# Altium schematic sheet DVT-Atlas-Driver1.SchDoc(DVT-Atlas-Driver1)
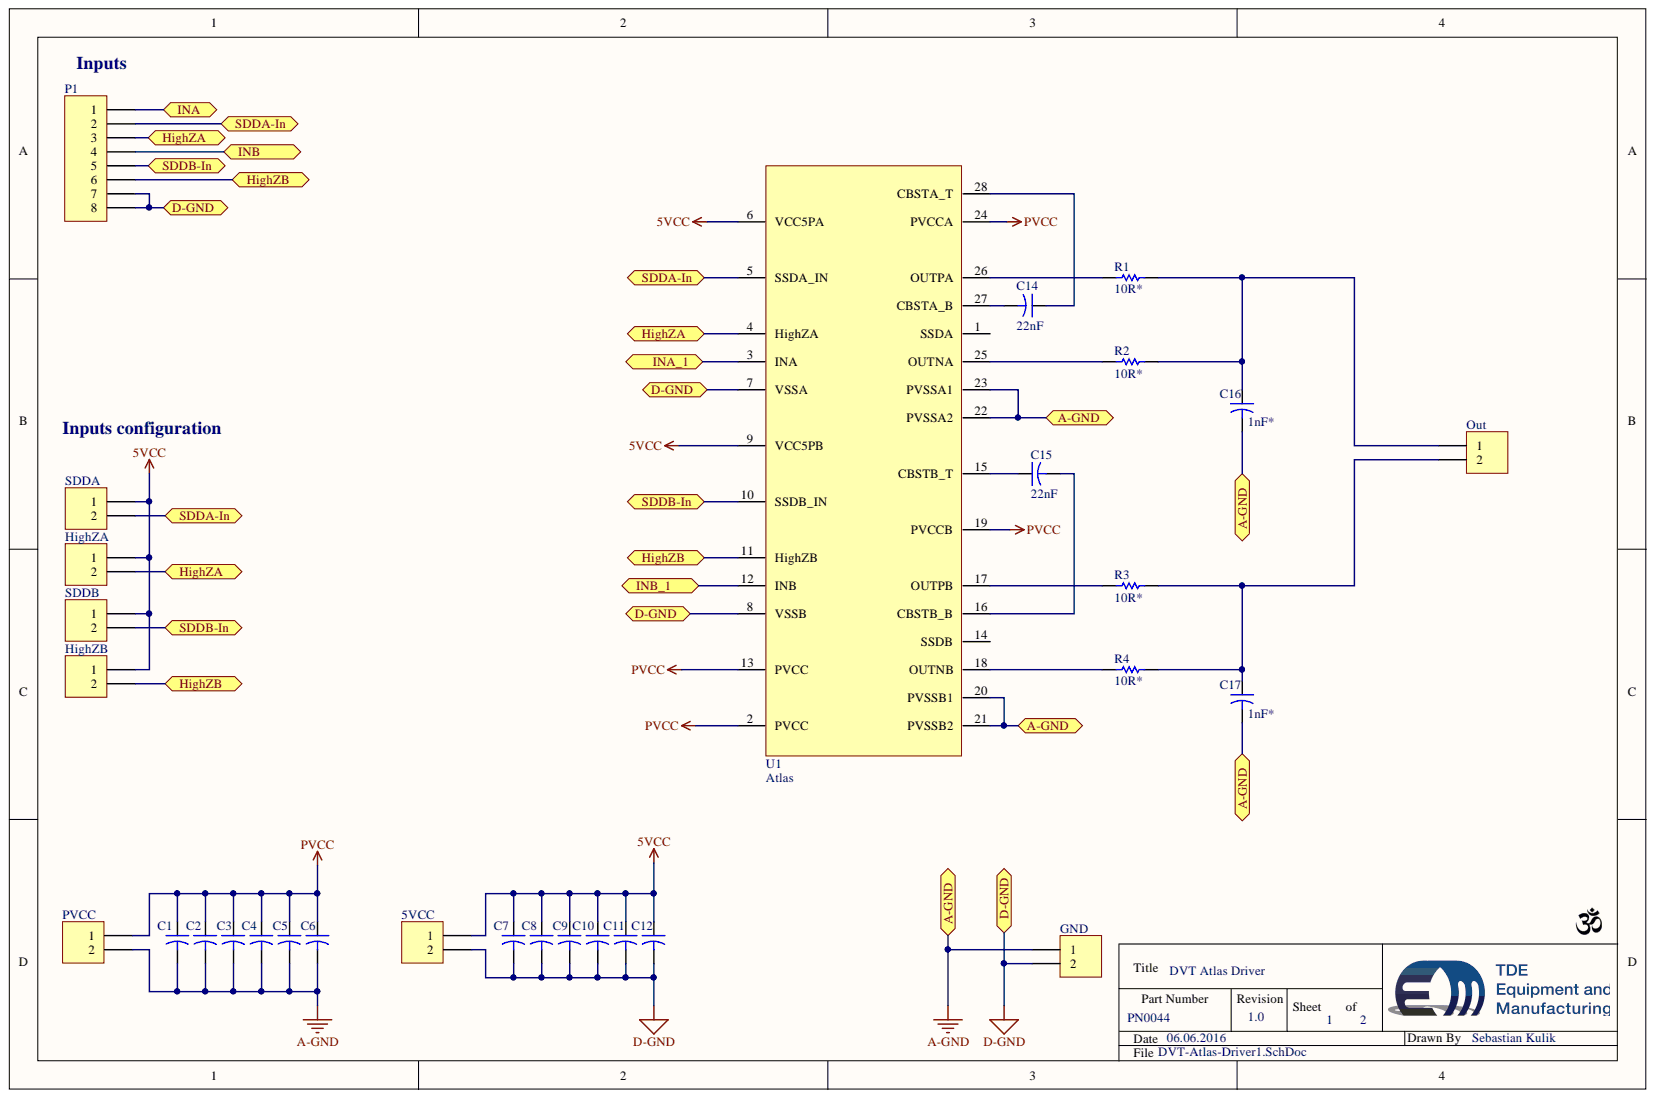
[diagram p0: DVT-Atlas-Driver1.SchDoc]

## Parts
5VCC  PHOENIX_1715721  [Phoenix-2-5_08]  (value not drawn)
*  Om  [Om-5mm]  (value not drawn)
+  Om  [Om-5mm]  (value not drawn)
C1  Capacitor-Dummy-THT  [Dummy-10mm]  (value not drawn)
C2  Capacitor-Dummy-THT  [Dummy-10mm]  (value not drawn)
C3  Capacitor-Dummy-THT  [Dummy-10mm]  (value not drawn)
C4  Capacitor-Dummy-THT  [Dummy-10mm]  (value not drawn)
C5  Capacitor-Dummy-THT  [Dummy-10mm]  (value not drawn)
C6  Capacitor-Dummy-THT  [Dummy-10mm]  (value not drawn)
C7  Capacitor-Dummy-THT  [Dummy-10mm]  (value not drawn)
C8  Capacitor-Dummy-THT  [Dummy-10mm]  (value not drawn)
C9  Capacitor-Dummy-THT  [Dummy-10mm]  (value not drawn)
C10  Capacitor-Dummy-THT  [Dummy-10mm]  (value not drawn)
C11  Capacitor-Dummy-THT  [Dummy-10mm]  (value not drawn)
C12  Capacitor-Dummy-THT  [Dummy-10mm]  (value not drawn)
C14  value 22nF  [Dummy-10mm]  (hidden comment: Capacitor-Dummy-THT)
C15  value 22nF  [Dummy-10mm]  (hidden comment: Capacitor-Dummy-THT)
C16  value 1nF*  [Dummy-10mm]  (hidden comment: Capacitor-Dummy-THT)
C17  value 1nF*  [Dummy-10mm]  (hidden comment: Capacitor-Dummy-THT)
GND  PHOENIX_1715721  [Phoenix-2-5_08]  (value not drawn)
HighZA  Plug_2_180_2.54  [HDR1X2]  (value not drawn)
HighZB  Plug_2_180_2.54  [HDR1X2]  (value not drawn)
Out  PHOENIX_1715721  [Phoenix-2-5_08]  (value not drawn)
P1  Plug_08_2.54  [HDR1X8_2.54]  (value not drawn)
PVCC  PHOENIX_1715721  [Phoenix-2-5_08]  (value not drawn)
R1  value 10R*  [Dummy-10mm]  (hidden comment: Resistor-Dummy-THT)
R2  value 10R*  [Dummy-10mm]  (hidden comment: Resistor-Dummy-THT)
R3  value 10R*  [Dummy-10mm]  (hidden comment: Resistor-Dummy-THT)
R4  value 10R*  [Dummy-10mm]  (hidden comment: Resistor-Dummy-THT)
SDDA  Plug_2_180_2.54  [HDR1X2]  (value not drawn)
SDDB  Plug_2_180_2.54  [HDR1X2]  (value not drawn)
U1  Atlas  [SOIC28]

## Ports
A-GND, D-GND, HIGHZA, HIGHZB, INA, INA_1, INB, INB_1, SDDA-IN, SDDB-IN

## Nets
5VCC: 5VCC.1 C7.1 C8.1 C9.1 C10.1 C11.1 C12.1 HighZA.1 HighZB.1 SDDA.1 SDDB.1 U1.6(VCC5PA) U1.9(VCC5PB)
PVCC: C1.1 C2.1 C3.1 C4.1 C5.1 C6.1 PVCC.1 U1.2(PVCC) U1.13(PVCC) U1.19(PVCCB) U1.24(PVCCA)
A-GND: C1.2 C2.2 C3.2 C4.2 C5.2 C6.2 C16.2 C17.2 GND.1 PVCC.2 U1.20(PVSSB1) U1.21(PVSSB2) U1.22(PVSSA2) U1.23(PVSSA1) ; port A-GND
D-GND: 5VCC.2 C7.2 C8.2 C9.2 C10.2 C11.2 C12.2 GND.2 P1.7 P1.8 U1.7(VSSA) U1.8(VSSB) ; port D-GND
INA: P1.1 ; port INA
INA_1: U1.3(INA) ; port INA_1
INB: P1.4 ; port INB
INB_1: U1.12(INB) ; port INB_1
NetC14_1: C14.1 U1.28(CBSTA_T)
NetC14_2: C14.2 U1.27(CBSTA_B)
NetC15_1: C15.1 U1.15(CBSTB_T)
NetC15_2: C15.2 U1.16(CBSTB_B)
NetC16_1: C16.1 Out.1 R1.2 R2.2
NetC17_1: C17.1 Out.2 R3.2 R4.2
NetHighZA_2: HighZA.2 P1.3 U1.4(HighZA) ; port HIGHZA
NetHighZB_2: HighZB.2 P1.6 U1.11(HighZB) ; port HIGHZB
NetP1_2: P1.2 SDDA.2 U1.5(SSDA_IN) ; port SDDA-IN
NetP1_5: P1.5 SDDB.2 U1.10(SSDB_IN) ; port SDDB-IN
NetR1_1: R1.1 U1.26(OUTPA)
NetR2_1: R2.1 U1.25(OUTNA)
NetR3_1: R3.1 U1.17(OUTPB)
NetR4_1: R4.1 U1.18(OUTNB)

Not connected: U1 1(SSDA) 14(SSDB)

## Library data of the parts
5VCC GND Out PVCC = 2Pol_1715721: Phoenix, 2 pol, 5.08mm, 22A, 715721; Deliverer PartNr: 3041529; Deliverer: Farnell; TDE-PN: CON0008; Component Kind: Standard; LatestRevisionDate: 02.05.2014; LatestRevisionNote: Re-released for DXP Platform.; Library Name: Connectors.IntLib; Manufacturer: Phoenix; Manufacturer PartNr: 1715721; PackageDescription: Phoenix-2-5_08; Signal Integrity: Connector; Publisher: Sebastian Kulik
C14 C15 = Capacitor-Dummy-THT: Deliverer PartNr: 537-3814; Deliverer: RS; Component Kind: Standard; Description: Capacitor-Dummy-THT; Library Name: Capacitors.IntLib; Manufacturer: -; Manufacturer PartNr: -; PackageDescription: -; Part Description: Capacitor-Dummy-THT; Published: 08.12.2015; Signal Integrity: Cap; Simulation: CAP; TDE-PN: -; Publisher: Sebastian Kulik
C16 C17 = Capacitor-Dummy-THT: Deliverer PartNr: 538-1168; Deliverer: RS; Component Kind: Standard; Description: Capacitor-Dummy-THT; Library Name: Capacitors.IntLib; Manufacturer: -; Manufacturer PartNr: -; PackageDescription: -; Part Description: Capacitor-Dummy-THT; Price: 0.116/5; Published: 08.12.2015; Signal Integrity: Cap; Simulation: CAP; TDE-PN: -; Publisher: Sebastian Kulik
HighZA HighZB SDDA SDDB = Plug_2_180_2.54: Plug, 2-Pin; Deliverer PartNr: 828-1581; Manufacturer: WE; Manufacturer PartNr: 61300211121; Deliverer: RS; TDE-PN: CON0010; Component Kind: Standard; LatestRevisionDate: 14.05.2014; LatestRevisionNote: Re-released for DXP Platform.; Library Name: Connectors.IntLib; Price: 0.118/5; Signal Integrity: Connector; Publisher: Sebastian Kulik
P1 = Plug_08_2.54: Plug, 08-Pin; Deliverer PartNr: 61300811121; Deliverer: WE; TDE-PN: CON0035; Component Kind: Standard; LatestRevisionDate: 11.11.2014; LatestRevisionNote: Re-released for DXP Platform.; Library Name: Connectors.IntLib; Manufacturer: WE; Manufacturer PartNr: 61300811121; Signal Integrity: Connector; Publisher: Sebastian Kulik
R1-R4 = Resistor-Dummy-THT: Deliverer PartNr: 148-017; Deliverer: RS; Component Kind: Standard; Description: Resistor-Dummy-THT; Library Name: Resistors.IntLib; Manufacturer: -; Manufacturer PartNr: -; PackageDescription: -; Part Desicription: Resistor-Dummy-THT; Price: 0.044/10; Published: 08.12.2015; Signal Integrity: Res; Simulation: RESISTOR; TDE-PN: -; Publisher: Sebastian Kulik
U1 = CHT_TIT3345E_CSOIC28_T: Gate driver, 30V, HT; Deliverer PartNr: CHT-TIT3345E-CSOIC28-T; Manufacturer: CISSOID; Deliverer: Future; TDE-PN: IC0075; Component Kind: Standard; Library Name: ICs.IntLib; Manufacturer PartNr: CHT-TIT3345E-CSOIC28-T; PackageDescription: SOIC28; Price: 481.89; Published: 12.05.2016; Publisher: Sebastian Kulik
* + = Om: Component Kind: Standard; Library Name: ICs.IntLib
C1-C12 = Capacitor-Dummy-THT: Component Kind: Standard; Deliverer: -; Deliverer PartNr: -; Description: Capacitor-Dummy-THT; Library Name: Capacitors.IntLib; Manufacturer: -; Manufacturer PartNr: -; PackageDescription: -; Part Description: Capacitor-Dummy-THT; Published: 08.12.2015; Signal Integrity: Cap; Simulation: CAP; TDE-PN: -; Value: Value; Publisher: Sebastian Kulik
